# Altium schematic sheet _sensors_and_IOs.SchDoc
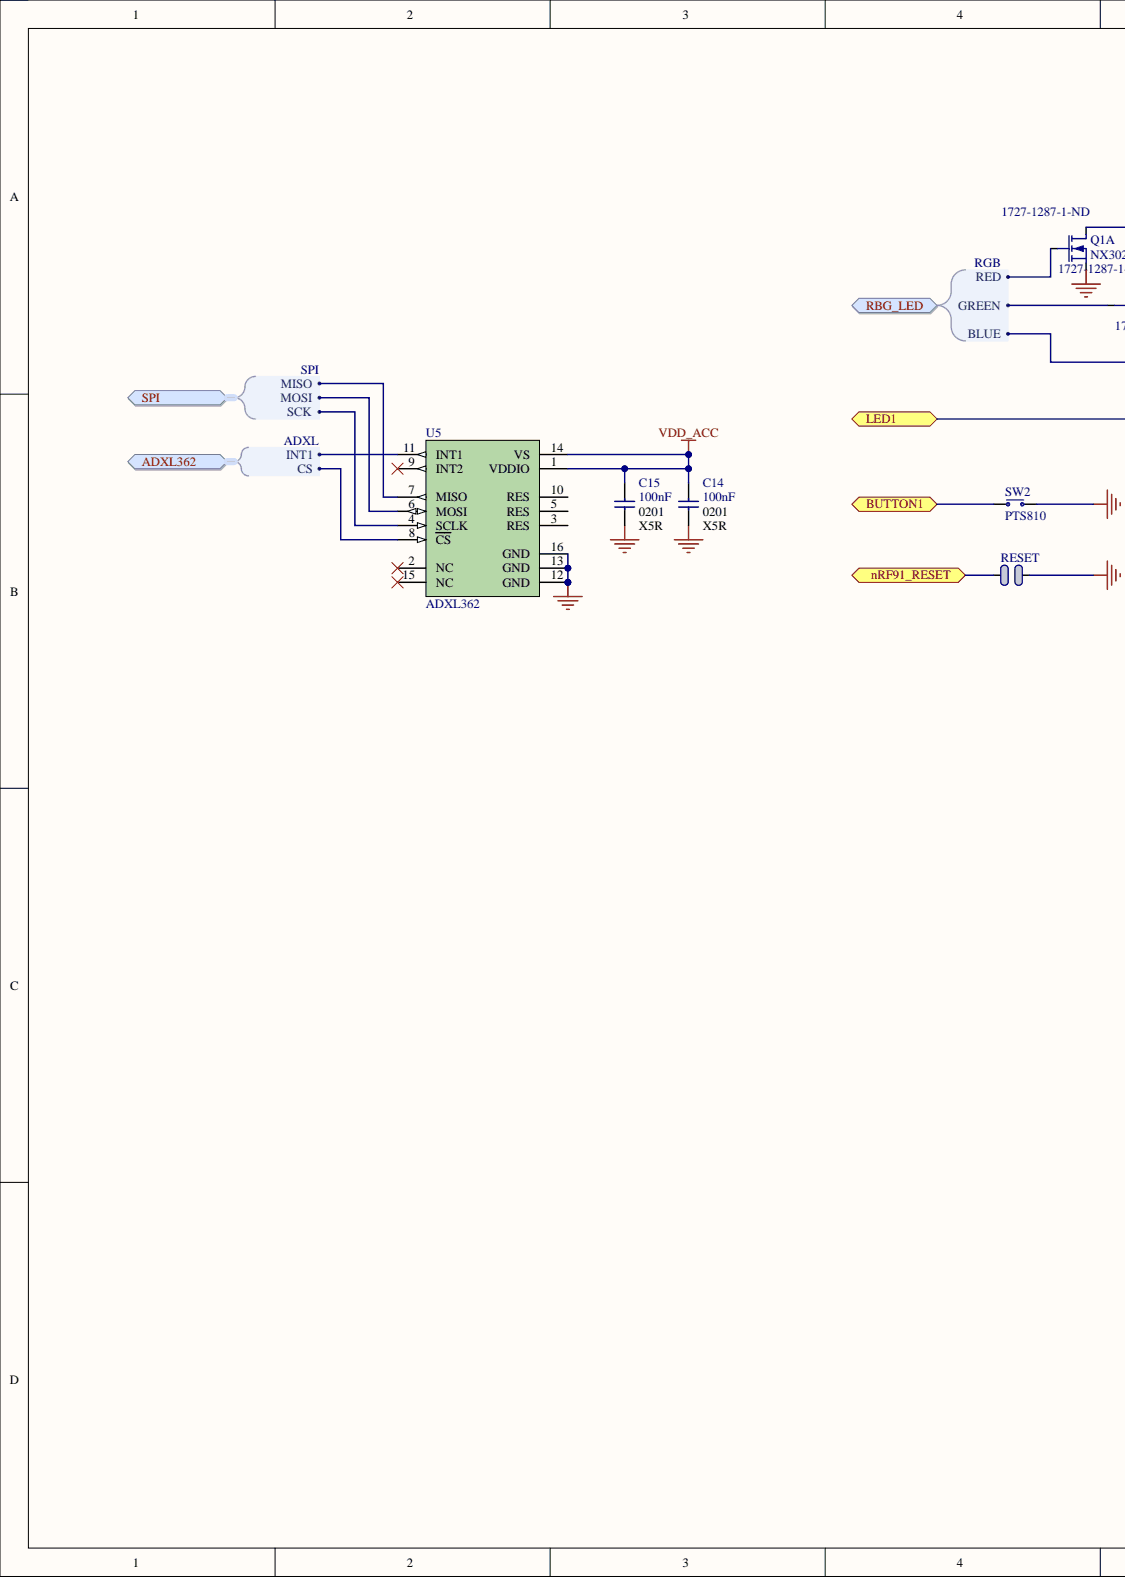
[diagram p0: _sensors_and_IOs.SchDoc, left]
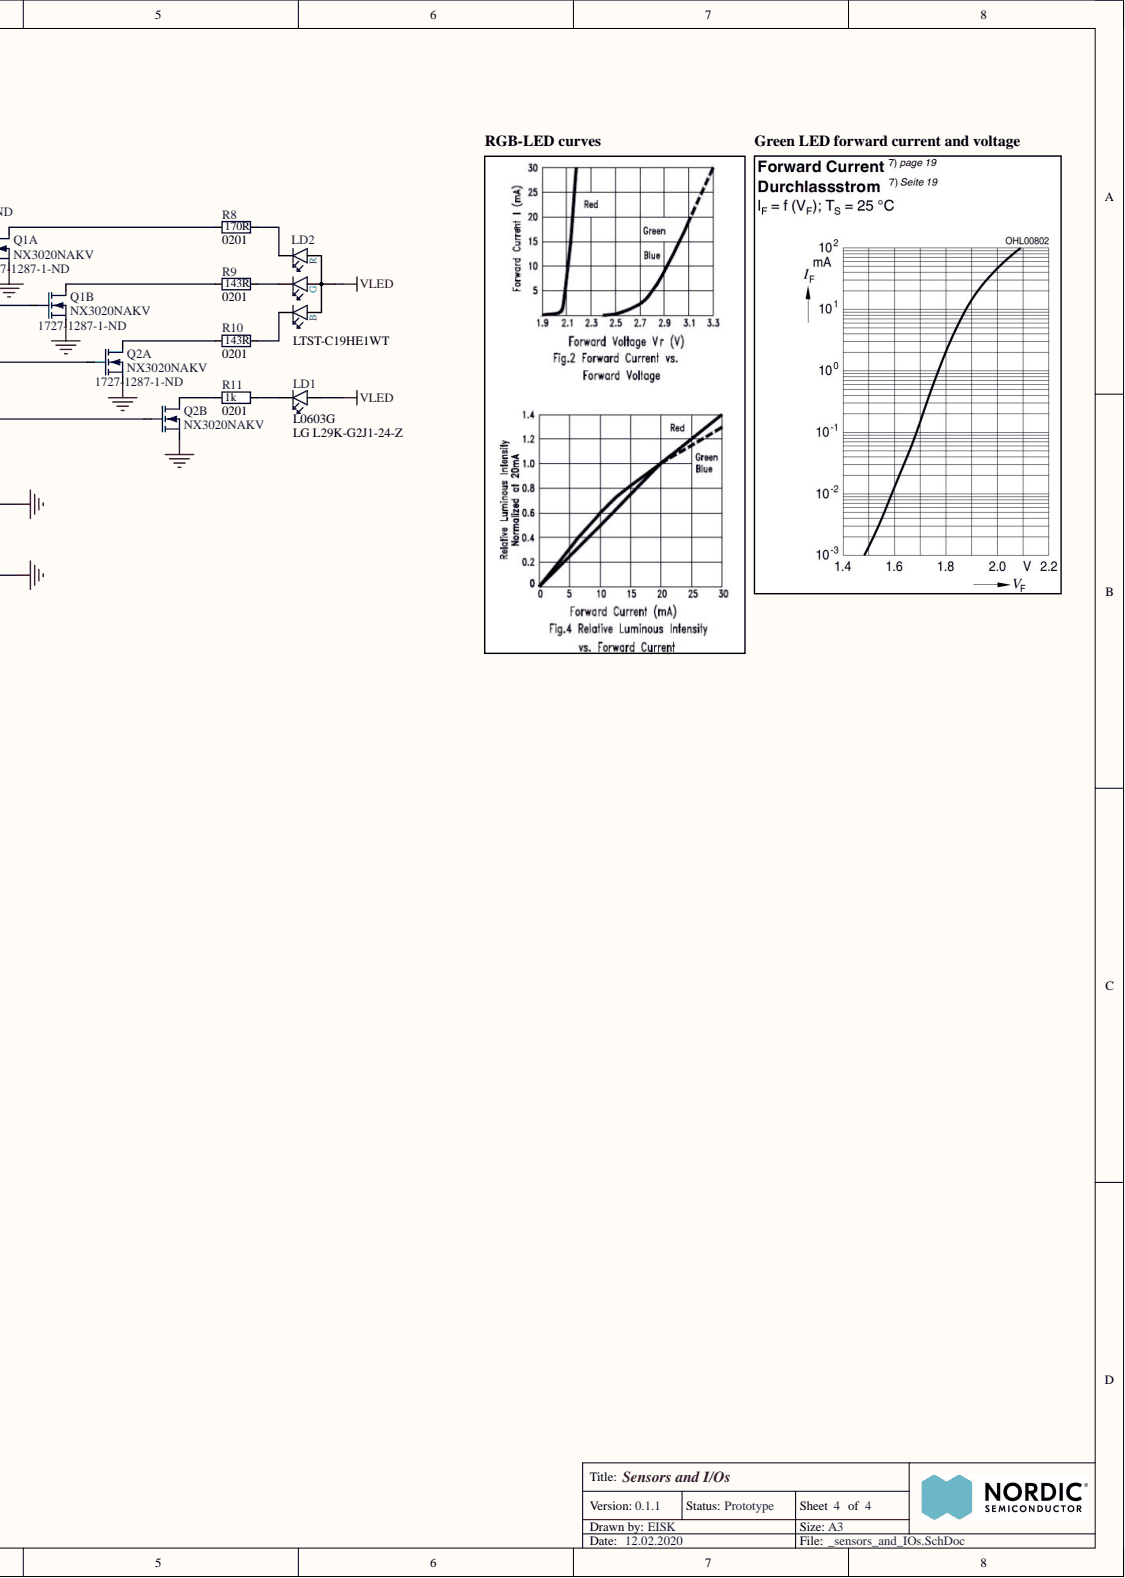
[diagram p1: _sensors_and_IOs.SchDoc, right]

## Parts
C14  100nF  Footprint Doc: 0201  TempCoeff: X5R  [CAPC0603X03L_C]
C15  100nF  Footprint Doc: 0201  TempCoeff: X5R  [CAPC0603X03L_C]
LD1  L0603G  Manufacturer Part Number: LG L29K-G2J1-24-Z  [LED_0603_G]
LD2  Manufacturer Part Number: LTST-C19HE1WT  [LED_RGB_0606]  (hidden comment: LED RGB)
Q1  NX3020NAKV  Supplier Part Number 2: 1727-1287-1-ND  [NX3020NAKV]  (parts A,B)
Q2  NX3020NAKV  Supplier Part Number 2: 1727-1287-1-ND  [NX3020NAKV]  (parts A,B)
R8  170R  Footprint Doc: 0201  [RESC0603X03L_C]
R9  143R  Footprint Doc: 0201  [RESC0603X03L_C]
R10  143R  Footprint Doc: 0201  [RESC0603X03L_C]
R11  1k  Footprint Doc: 0201  [RESC0603X03L_C]
RESET  Solderbridge  [Solderbridge]  (value not drawn)  (no BOM)
SW2  PTS810  [BTN_PTS810]
U5  ADXL362  [LGA50P322X300X114-16N]

## Ports
ADXL362, ADXL362.CS, ADXL362.INT1, BUTTON1, LED1, nRF91_RESET, RBG_LED, RBG_LED.BLUE, RBG_LED.GREEN, RBG_LED.RED, SPI, SPI.MISO, SPI.MOSI, SPI.SCK

## Nets
GND: C14.2 C15.2 Q1.1 Q1.4 Q2.1 Q2.4 RESET.1 SW2.1 U5.12(GND) U5.13(GND) U5.16(GND)
VDD_ACC: C14.1 C15.1 U5.1(VDDIO) U5.14(VS)
VLED: LD1.2 LD2.4
BUTTON1: SW2.2 ; port BUTTON1
LED1: Q2.5 ; port LED1
nRF91_RESET: RESET.2 ; port nRF91_RESET
NetLD1_1: LD1.1 R11.2
NetLD2_1: LD2.1 R8.2
NetLD2_2: LD2.2 R9.2
NetLD2_3: LD2.3 R10.2
NetQ1_2: Q1.2 ; port RBG_LED
NetQ1_3: Q1.3 R9.1
NetQ1_5: Q1.5 ; port RBG_LED
NetQ1_6: Q1.6 R8.1
NetQ2_2: Q2.2 ; port RBG_LED
NetQ2_3: Q2.3 R11.1
NetQ2_6: Q2.6 R10.1
NetU5_4: U5.4(SCLK) ; port SPI
NetU5_6: U5.6(MOSI) ; port SPI
NetU5_7: U5.7(MISO) ; port SPI
NetU5_8: U5.8(CS) ; port ADXL362
NetU5_11: U5.11(INT1) ; port ADXL362

Not connected: U5 2(NC) 3(RES) 5(RES) 9(INT2) 10(RES) 15(NC)

## Library data of the parts
C14 C15 = (no symbol name): Capacitor, X5R, ±10%, 10V; Artikkelnr Noca: N.A.; Component Kind: Standard; LatestRevisionDate: 2014-11-13; Library Name: _components.SchLib; Note: 10V; Pin Count: 2; Published: 2014-11-13; Value: 100nF; Publisher: Nordic Semiconductor
LD1 = D:LED: LED, Green, 0603, 570nm, Vf=1.7V, 2.24..5.6mcd, - 40 to +100°C; Manufacturer: Osram; Part Number: LG L29K Smartled Green; Part number IPS: N.A.; Artikkelnr Noca: 13019147; Note: 2.24..5.6 mcd, Vfmin=1.7V; Component Kind: Standard; ComponentLink1Description: Datasheet; ComponentLink2Description: Supplier link; Footprint Doc: 0603; HelpURL: http://www.osram-os.com/Graphics/XPic2/00077107_0.pdf; LatestRevisionDate: 2017-08-21; Library Name: _components.SchLib; Pin Count: 2; Published: 2014-10-28; Supplier 1: Digi-Key; Supplier 2: Mouser; Supplier Part Number 1: 475-2709-1-ND; Supplier Part Number 2: 720-LGL29KG2J124Z; Value: L0603G; Publisher: Nordic Semiconductor
LD2 = (no symbol name): RGB LED 1.60 mm x 1.60 mm SMD; 2nd source part number: 859-LTST-C19HE1WT; Manufacturer: Lite-On Inc; Part number IPS: 00000007798; Artikkelnr Noca: N.A.; 2nd source Supplier: Mouser; Artikkelnr Simpro: N.A.; Component Kind: Standard; Datasheet: http://optoelectronics.liteon.com/upload/download/DS22-2008-0044/S_110_LTST-C19HE1WT.pdf; Footprint Doc: LED_RGB_0606; LatestRevisionDate: 2016-09-23; Library Name: _components.SchLib; Part Number: LTST-C19HE1WT; Pin Count: 4; Published: 2016-09-23; Supplier: Digi-Key; Supplier part number: 160-2162-1-ND; Value: LED RGB; Publisher: Nordic Semiconductor
Q1 Q2 = (no symbol name): MOSFET 2N-CH 30V 0.2A SOT666; Manufacturer: Nexperia; Manufacturer Part Number: NX3020NAKV,115; Artikkelnr Noca: N.A.; Component Kind: Standard; ComponentLink1Description: Datasheet; ComponentLink1URL: https://assets.nexperia.com/documents/data-sheet/NX3020NAKV.pdf; ComponentLink2Description: Supplier link; Footprint Doc: SOT666; LatestRevisionDate: 2018-12-13; Library Name: _components.SchLib; Part Number: NX3020NAKV; Part number IPS: N.A.; Pin Count: 6; Published: 2018-12-13; Supplier 1: Mouser; Supplier 2: Digi-Key; Supplier Part Number 1: 771-NX3020NAKV115; Value: NX3020NAKV; Publisher: Nordic Semiconductor
R8-R11 = RES:0201: Component Kind: Standard; LatestRevisionDate: 2019-06-11; Library Name: _components.SchLib; Package: 0201; Publisher: Nordic Semiconductor
  R8: Value: 170R
  R9 R10: Value: 143R
  R11: Value: 1k
RESET = (no symbol name): Note: Not in BOM; ComponentLink1Description: Datasheet; ComponentLink2Description: Supplier link; Description: Solderbridge; Footprint Doc: Solderbridge; Library Name: _components.SchLib; Pin Count: 2; Published: 2019-07-17; Value: Solderbridge; Publisher: Nordic Semiconductor
SW2 = BUTTON: SWITCH TACTILE SPST-NO 0.05A 16V; Manufacturer: C&K; Manufacturer Part Number: PTS810 SJM 250 SMTR LFS; Note: NO; Component Kind: Standard; ComponentLink1Description: Datasheet; ComponentLink1URL: https://www.ckswitches.com/media/1476/pts810.pdf; ComponentLink2Description: Digi-Key; ComponentLink2URL: https://www.digikey.no/product-detail/en/c-k/PTS810-SJM-250-SMTR-LFS/CKN10502DKR-ND/4176674; HelpDoc: https://www.ckswitches.com/media/1476/pts810.pdf; Library Name: _components.SchLib; Supplier 1: Digi-Key; Supplier Part Number 1: CKN10502CT-ND; Value: PTS810
U5 = (no symbol name): Micropower, 3-Axis, ±2 g/±4 g/±8 g Digital Output MEMS Accelerometer; Manufacturer: Analog Devices; Part number IPS: 00000001799; Artikkelnr Noca: N.A.; Component Kind: Standard; ComponentLink1Description: Datasheet; ComponentLink1URL: http://www.analog.com/media/en/technical-documentation/data-sheets/ADXL362.pdf; Footprint Doc: LGA-16; HelpURL: https://www.analog.com/media/en/technical-documentation/data-sheets/ADXL362.pdf; LatestRevisionDate: 2019-01-11; Library Name: _components.SchLib; Manufacturer Part Number: ADXL362; Part Number: ADXL362; Pin Count: 16; Published: 2019-01-11; Supplier 1: Digi-Key; Supplier 2: Mouser; Supplier Part Number 1: ADXL362BCCZ-RL7CT-ND; Supplier Part Number 2: 584-ADXL362BCCZ-R7; Value: ADXL362; Publisher: Nordic Semiconductor
